# Altium schematic sheet Top.SchDoc(Designator)
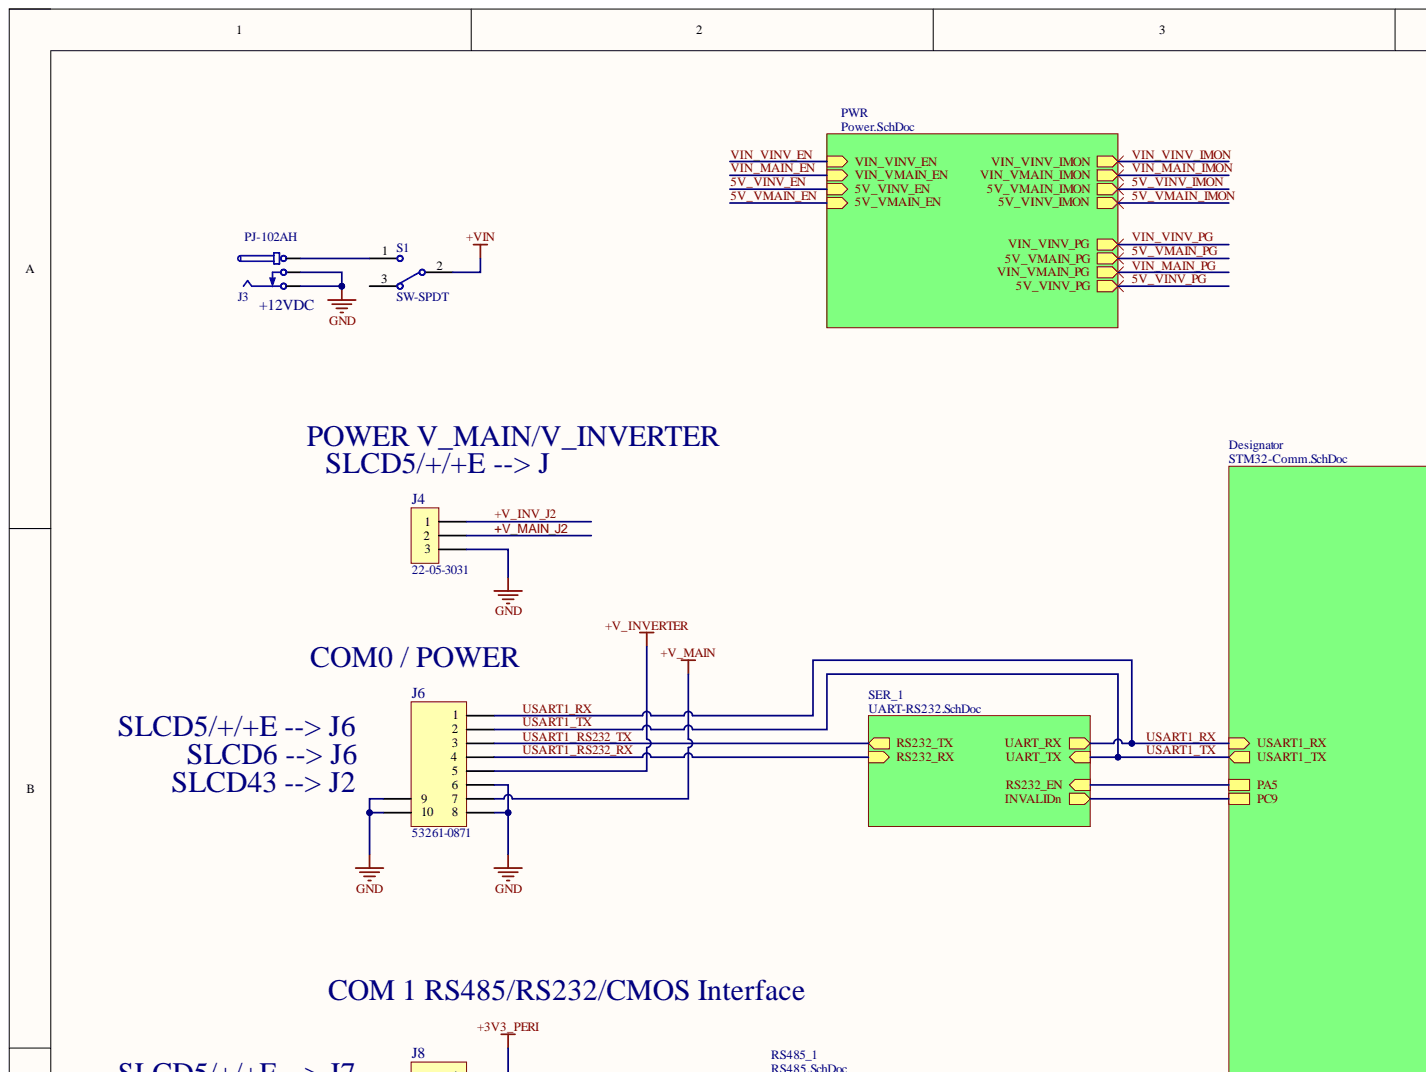
[diagram p0: Top.SchDoc, top left]
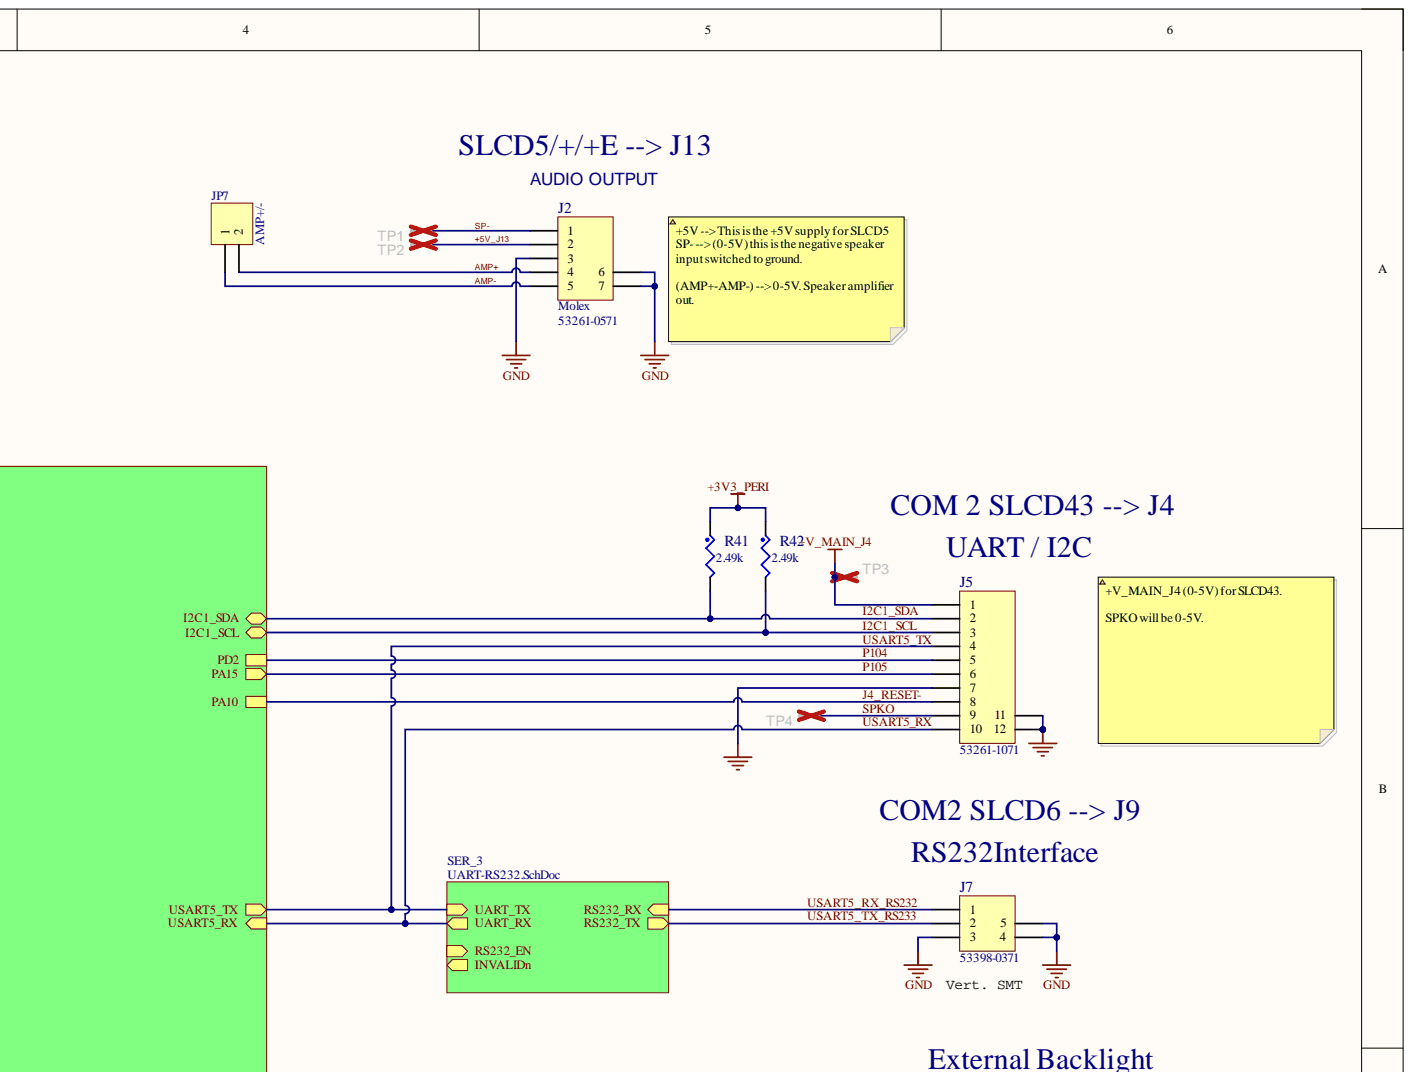
[diagram p1: Top.SchDoc, top right]
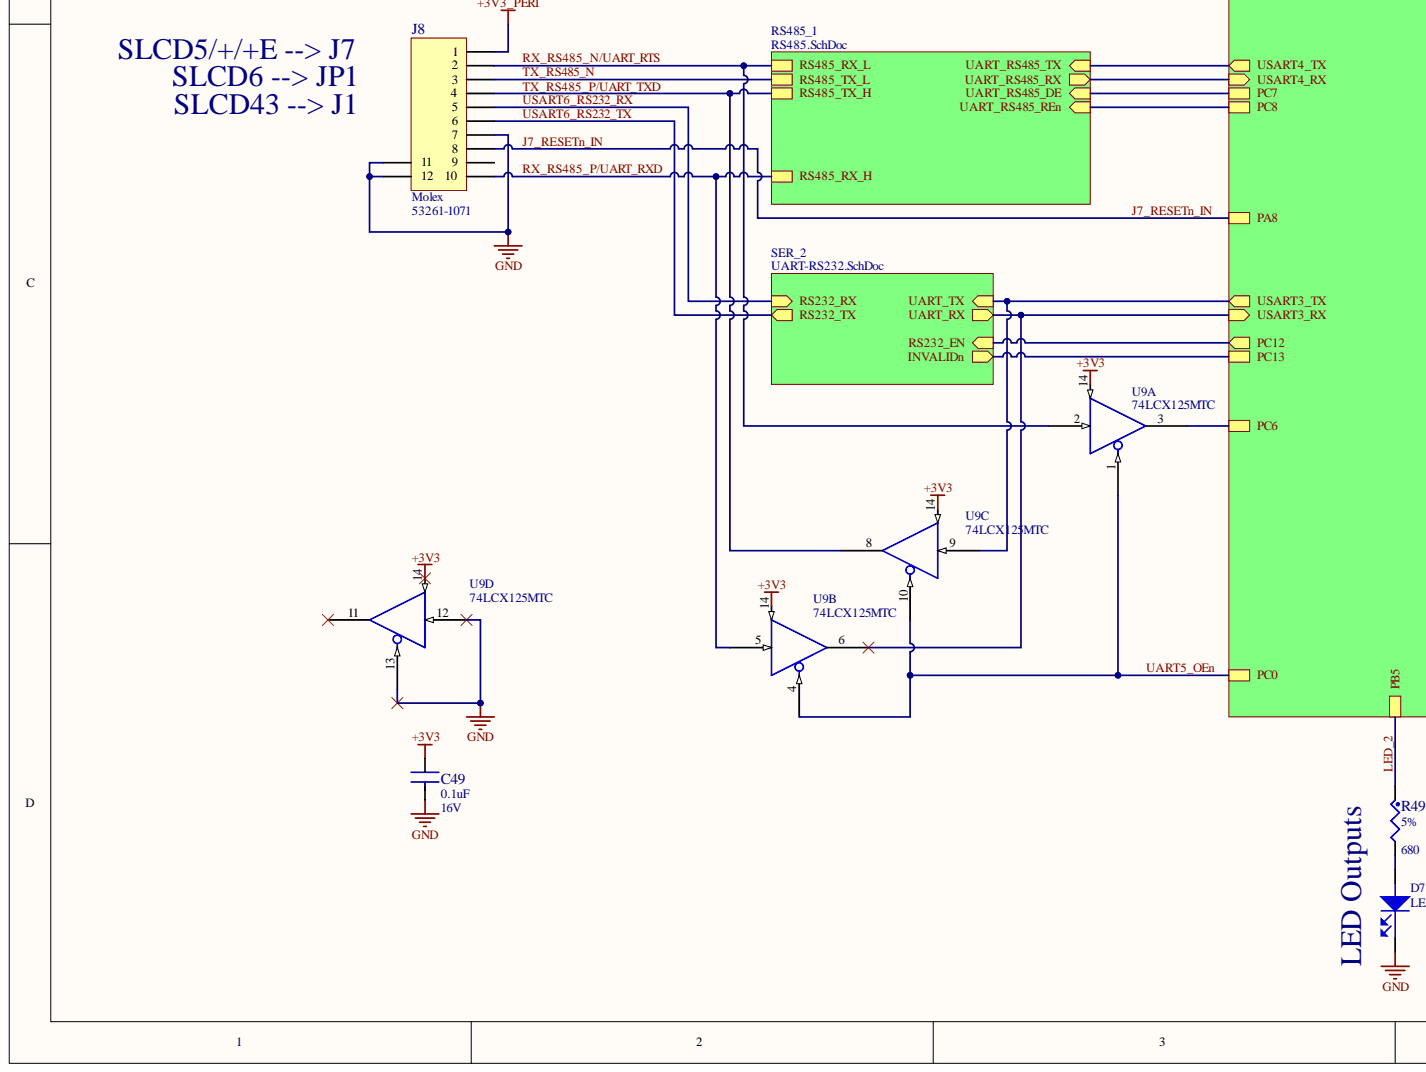
[diagram p2: Top.SchDoc, bottom left]
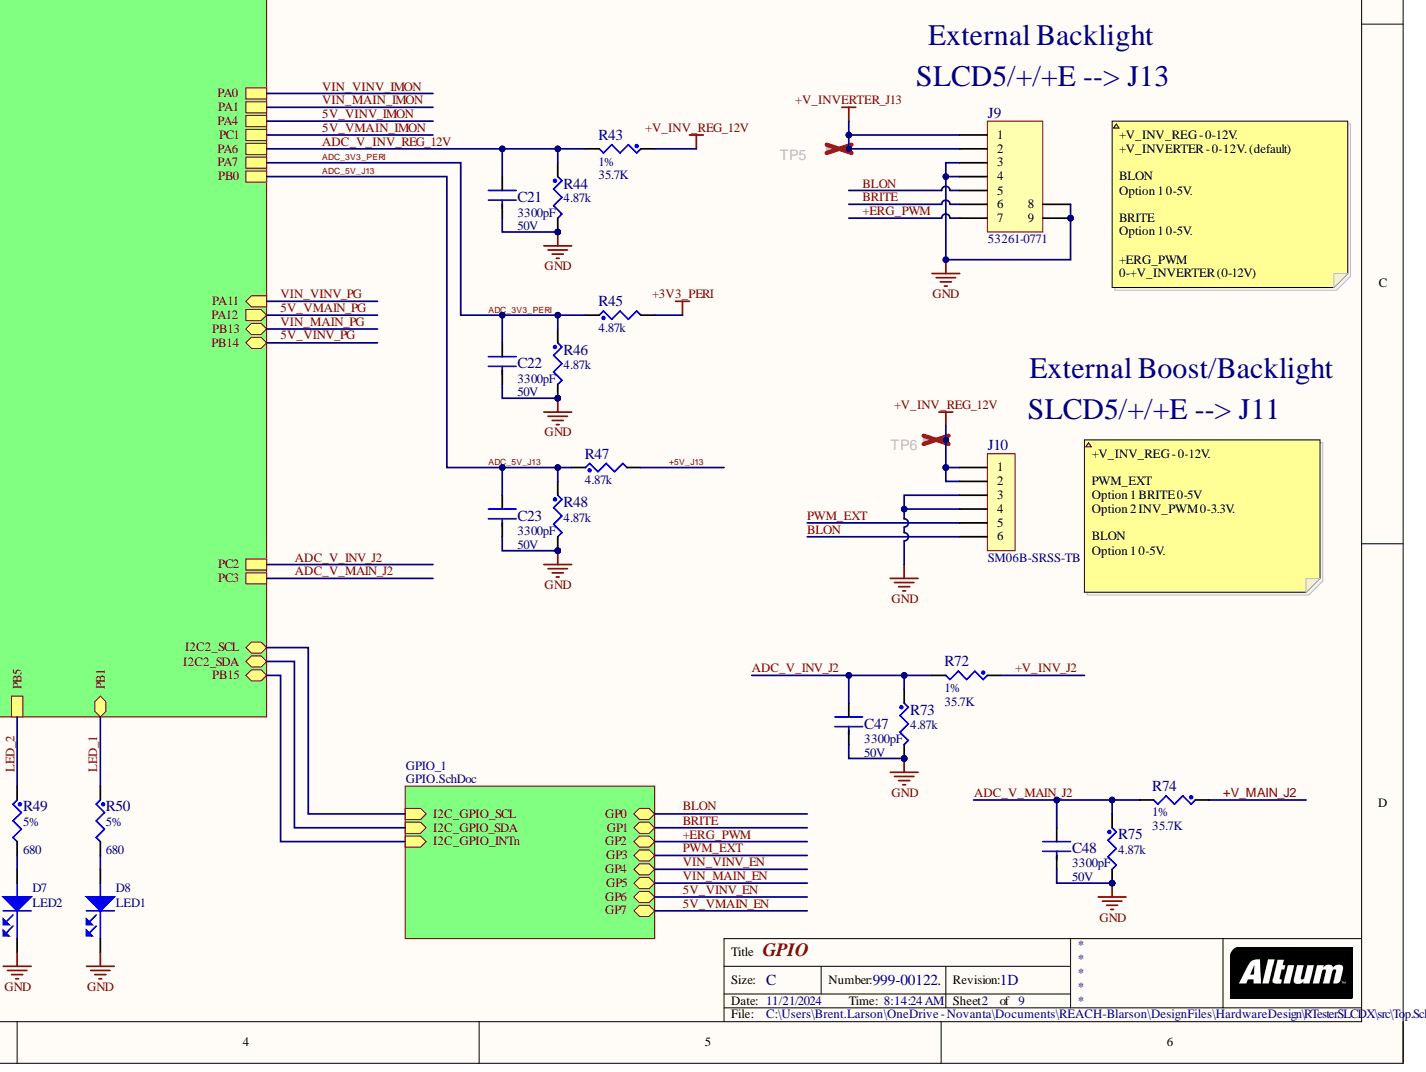
[diagram p3: Top.SchDoc, bottom right]

## Parts
C21  Val: 3300pF  Volt: 50V  [scap-0603]  (hidden comment: C0603C332K5RACTU)
C22  Val: 3300pF  Volt: 50V  [scap-0603]  (hidden comment: C0603C332K5RACTU)
C23  Val: 3300pF  Volt: 50V  [scap-0603]  (hidden comment: C0603C332K5RACTU)
C47  Val: 3300pF  Volt: 50V  [scap-0603]  (hidden comment: C0603C332K5RACTU)
C48  Val: 3300pF  Volt: 50V  [scap-0603]  (hidden comment: C0603C332K5RACTU)
C49  Val: 0.1uF  Volt: 16V  [scap-0603]  (hidden comment: C0603C104K4RACTU)
D7  Val: LED2  [sled-0603]  (hidden comment: LNJ308G8LRA)
D8  Val: LED1  [sled-0603]  (hidden comment: LNJ308G8LRA)
J2  53261-0571  Mfg: Molex  [scon-MLX_53261-05]
J3  PJ-102AH  [TCON-CUI_PJ-102BH]
J4  22-05-3031  [PENDING]
J5  53261-1071  [scon-MLX_53261-10]
J6  53261-0871  [scon-MLX_53261-08]
J7  53398-0371  [PENDING]
J8  53261-1071  Mfg: Molex  [scon-MLX_53261-10]
J9  53261-0771  [scon-MLX_53261-07]
J10  SM06B-SRSS-TB  [PENDING]
JP7  AMP+/-  [HDR1X2]
R41  Val: 2.49k  [sres-0402]  (hidden comment: ERJ-2RKF2491X)
R42  Val: 2.49k  [sres-0402]  (hidden comment: ERJ-2RKF2491X)
R43  Tol: 1%  Val: 35.7K  [sres-0603]  (hidden comment: RC0603FR-0735K7L)
R44  Val: 4.87k  [sres-0402]  (hidden comment: ERJ-2RKF4871X)
R45  Val: 4.87k  [sres-0402]  (hidden comment: ERJ-2RKF4871X)
R46  Val: 4.87k  [sres-0402]  (hidden comment: ERJ-2RKF4871X)
R47  Val: 4.87k  [sres-0402]  (hidden comment: ERJ-2RKF4871X)
R48  Val: 4.87k  [sres-0402]  (hidden comment: ERJ-2RKF4871X)
R49  Tol: 5%  [sres-0603]  (hidden comment: ERJ-3GEYJ681V)
R50  Tol: 5%  [sres-0603]  (hidden comment: ERJ-3GEYJ681V)
R72  Tol: 1%  Val: 35.7K  [sres-0603]  (hidden comment: RC0603FR-0735K7L)
R73  Val: 4.87k  [sres-0402]  (hidden comment: ERJ-2RKF4871X)
R74  Tol: 1%  Val: 35.7K  [sres-0603]  (hidden comment: RC0603FR-0735K7L)
R75  Val: 4.87k  [sres-0402]  (hidden comment: ERJ-2RKF4871X)
S1  SW-SPDT  [TL36WW15050]
TP1  ART-TP-40MIL  [PENDING]  (value not drawn)
TP2  ART-TP-40MIL  [PENDING]  (value not drawn)
TP3  ART-TP-40MIL  [PENDING]  (value not drawn)
TP4  ART-TP-40MIL  [PENDING]  (value not drawn)
TP5  ART-TP-40MIL  [PENDING]  (value not drawn)
TP6  ART-TP-40MIL  [PENDING]  (value not drawn)
U9  74LCX125MTC  [PENDING]  (parts A,B,C,D)

## Nets
+3V3: C49.1 U9.14
+3V3_PERI: J8.1 R41.1 R42.1 R45.2
+V_INV_REG_12V: J10.1 J10.2 R43.1 TP6.1
+V_INVERTER: J6.5
+V_INVERTER_J13: J9.1 J9.2 TP5.1
+V_MAIN: J6.7
+V_MAIN_J4: J5.1 TP3.1
+VIN: S1.2
GND: C21.2 C22.2 C23.2 C47.2 C48.2 C49.2 D7.C D8.C J2.3 J2.6 J2.7 J3.2 J3.3 J4.3 J5.7 J5.11 J5.12 J6.6 J6.8 J6.9 J6.10 J7.3 J7.4 J7.5 J8.7 J8.11 J8.12 J9.3 J9.4 J9.8 J9.9 J10.3 J10.4 R44.2 R46.2 R48.2 R73.2 R75.2 U9.7 U9.12 U9.13
5V_VINV_EN: J2.2 R47.2 TP2.1  [also labelled +5V_J13]
+ERG_PWM: J9.7
+V_INV_J2: J4.1 R72.1
+V_MAIN_J2: J4.2 R74.1
ADC_3V3_PERI: C22.1 R45.1 R46.1
ADC_5V_J13: C23.1 R47.1 R48.1
ADC_V_INV_J2: C47.1 R72.2 R73.1
ADC_V_INV_REG_12V: C21.1 R43.2 R44.1
ADC_V_MAIN_J2: C48.1 R74.2 R75.1
AMP+: J2.4 JP7.2
AMP-: J2.5 JP7.1
BLON: J9.5 J10.6
BRITE: J9.6
I2C1_SCL: J5.3 R42.2
I2C1_SDA: J5.2 R41.2
J4_RESET-: J5.8
J7_RESETn_IN: J8.8
LED_1: R50.1
LED_2: R49.1
P104: J5.5
P105: J5.6
PWM_EXT: J10.5
RX_RS485_N/UART_RTS: J8.2 U9.2
RX_RS485_P/UART_RXD: J8.10 U9.5
SP-: J2.1 TP1.1
SPKO: J5.9 TP4.1
TX_RS485_N: J8.3
TX_RS485_P/UART_TXD: J8.4 U9.8
UART5_OEn: U9.1 U9.4 U9.10
USART1_RS232_RX: J6.4
USART1_RS232_TX: J6.3
USART1_RX: J6.1
USART1_TX: J6.2
USART5_RX: J5.10
USART5_RX_RS232: J7.1
USART5_TX: J5.4
USART5_TX_RS233: J7.2
USART6_RS232_RX: J8.5
USART6_RS232_TX: J8.6
NetD7_A: D7.A R49.2
NetD8_A: D8.A R50.2
NetJ3_1: J3.1 S1.1
NetJ8_9: J8.9
NetS1_3: S1.3
NetU9_3: U9.3
NetU9_6: U9.6
NetU9_9: U9.9
NetU9_11: U9.11
5V_VINV_IMON:
5V_VINV_PG:
5V_VMAIN_EN:
5V_VMAIN_IMON:
5V_VMAIN_PG:
I2C2_SCL:
I2C2_SDA:
I2C_GPIO_INTn:
INVALIDn:
PA5:
PC7:
PC8:
PC12:
RS232_EN:
UART_RS485_RX:
UART_RS485_TX:
VIN_MAIN_EN:
VIN_MAIN_IMON:
VIN_MAIN_PG:
VIN_VINV_EN:
VIN_VINV_IMON:
VIN_VINV_PG:

## Library data of the parts
C21-C23 C47 C48 = CAP, SMD, CER 3300pF 50V 10% X7R (0603): Cap, Non-Polorized; Database Table Name: Capacitor$; Mfg: Kemet; Pkg_Size: 0603 (1608 Metric); Tol: 10%; Component Kind: Standard; Mfr_PN: C0603C332K5RACTU; Reach_PN: 11-0148-01; Supplier 1: Digi-Key; Supplier Part Number 1: 399-1086-1-ND; Library Name: REACH TECH.DbLib
C49 = CAP, SMD, CER 0.1UF 16V 10% X7R (0603): Cap, Non-Polorized; Database Table Name: Capacitor$; Mfg: Kemet; Pkg_Size: 0603; Tol: 10%; Component Kind: Standard; Mfr_PN: C0603C104K4RACTU; Reach_PN: 11-0079-00; Supplier 1: Digi-Key; Supplier Part Number 1: 399-1096-6-ND; Library Name: REACH TECH.DbLib
D7 D8 = LED, SMD, GREEN SS TYPE LOW CUR (0603): LED, Pins=[a,c]; Database Table Name: LED$; Mfg: Panasonic-SSG; Pkg_Size: 0603; Value: GRN; Component Kind: Standard; Mfr_PN: LNJ308G8LRA; Reach_PN: 15-0021-01; Supplier 1: Digi-Key; Supplier Part Number 1: P521DKR-ND; Library Name: REACH TECH.DbLib
J2 = CONN, SMD, 5pin, 1.25 Wire-to-board, (Molex 53261-05xx): HDR, 5-PIN with 2 electrical anchor pins; Database Table Name: Connector$; Val: Value; Component Kind: Standard; Mfr_PN: 53261-0571; Reach_PN: 13-0082-00; Supplier 1: Digi-Key; Supplier Part Number 1: WM7623CT-ND; Library Name: REACH TECH.DbLib
J3 = CONN, JACK, CONN PWR JACK 2.1X5.5MM: CONN PWR JACK 2.5X5.5MM HIGH CUR; Database Table Name: Connector$; Mfg: CUI; Component Kind: Standard; Mfr_PN: PJ-102AH; Reach_PN: 13-0107-00; Supplier 1: Digi-Key; Supplier Part Number 1: CP-102AH-ND; Library Name: REACH TECH.DbLib
J4 = CONN, Male Header,  3-Pin .100 R/A, Tin: HDR, 3-pin; Database Table Name: Connector$; Mfg: Molex; Val: Value; Component Kind: Standard; Mfr_PN: 22-05-3031; Reach_PN: 13-0023-03; Supplier 1: Digi-Key; Supplier Part Number 1: WM4301-ND; Library Name: REACH TECH.DbLib
J5 = CONN, SMD, 10pin, 1.25 Wire-to-board, (Molex 53261-10xx): HDR, 10-PIN with 2 electrical anchor pins; Database Table Name: Connector$; Mfg: Molex; Val: Value; Component Kind: Standard; Mfr_PN: 53261-1071; Reach_PN: 13-0058-00; Supplier 1: Digi-Key; Supplier Part Number 1: WM7628DKR-ND; Library Name: REACH TECH.DbLib
J6 = CONN, SMD, 8pin, 1.25 Wire-to-board, (Molex 53261-08xx): HDR, 8-PIN with 2 electrical anchor pins; Database Table Name: Connector$; Mfg: Molex; Val: Value; Component Kind: Standard; Mfr_PN: 53261-0871; Reach_PN: 13-0008-00; Supplier 1: Digi-Key; Supplier Part Number 1: WM7626DKR-ND; Library Name: REACH TECH.DbLib
J7 = CONN, SMD, 1.25mm vertical 3pos.: HDR, 3-PIN with 2 electrical anchor pins; Database Table Name: Connector$; Mfg: Molex; Val: Value; Component Kind: Standard; Mfr_PN: 53398-0371; Reach_PN: 13-0119-00; Supplier 1: Digi-Key; Supplier Part Number 1: WM7607CT-ND; Library Name: REACH TECH.DbLib
J8 = CONN, SMD, 10pin, 1.25 Wire-to-board, (Molex 53261-10xx): HDR, 10-PIN with 2 electrical anchor pins; Database Table Name: Connector$; Val: Value; Component Kind: Standard; Mfr_PN: 53261-1071; Reach_PN: 13-0058-00; Supplier 1: Digi-Key; Supplier Part Number 1: WM7628DKR-ND; Library Name: REACH TECH.DbLib
J9 = CONN, SMD, 7pin, 1.25 Wire-to-board, (Molex 53261-07xx): HDR, 7-PIN with 2 electrical anchor pins; Database Table Name: Connector$; Mfg: Molex; Val: Value; Component Kind: Standard; Mfr_PN: 53261-0771; Reach_PN: 13-0115-00; Supplier 1: Digi-Key; Supplier Part Number 1: WM7625CT-ND; Library Name: REACH TECH.DbLib
J10 = CONN HEADER SMD R/A 6POS 1MM JST SR: HDR, 6-PIN; Database Table Name: Connector$; Mfg: JST; Val: Value; Component Kind: Standard; Mfr_PN: SM06B-SRSS-TB; Reach_PN: 13-0244-01; Supplier 1: Digi-Key; Supplier Part Number 1: 455-SM06B-SRSS-TBTR-ND; Library Name: REACH TECH.DbLib
JP7 = Header 2: Header, 2-Pin; Mfr_PN: PHA116--P0201GFROHS; Mfg: MMT; Component Kind: Standard; LatestRevisionDate: 17-Jul-2002; LatestRevisionNote: Re-released for DXP Platform.; Library Name: Miscellaneous Connectors.IntLib; Reach_PN: 12-0006-00; Signal Integrity: Connector; Publisher: Altium Limited
R41 R42 = RES SMD 2.49K OHM 1% 1/10W 0402: Default 2-pin Resistor; Database Table Name: Resistor$; Mfg: Panasonic-ECG; Pkg_Size: 0402; Tol: 1.00%; Watt: 1/10W; Component Kind: Standard; Mfr_PN: ERJ-2RKF2491X; Reach_PN: 10-0356-00; Supplier 1: Digi-Key; Supplier Part Number 1: P2.49KLTR-ND; Library Name: REACH TECH.DbLib
R43 R72 R74 = RES, SMD, 35.7K OHM 1/10W 1% (0603): Default 2-pin Resistor; Database Table Name: Resistor$; Mfg: Yageo; Pkg_Size: 0603; Watt: 1/10W; Component Kind: Standard; Mfr_PN: RC0603FR-0735K7L; Reach_PN: 10-0325-00; Supplier 1: Digi-Key; Supplier Part Number 1: 311-35.7KHRCT-ND; Library Name: REACH TECH.DbLib
R44-R48 R73 R75 = RES SMD 4.87K OHM 1% 1/10W 0402: Default 2-pin Resistor; Database Table Name: Resistor$; Mfg: Panasonic-ECG; Tol: 1.00%; Watt: 1/10W; Component Kind: Standard; Mfr_PN: ERJ-2RKF4871X; Reach_PN: 10-0417-00; Supplier 1: Digi-Key; Supplier Part Number 1: P4.87KLTR-ND; Library Name: REACH TECH.DbLib
R49 R50 = RES, SMD, 680 OHM 1/10W 5% (0603): Default 2-pin Resistor; Database Table Name: Resistor$; Mfg: Panasonic-ECG; Pkg_Size: 0603 (1608 Metric); Val: 680; Component Kind: Standard; Mfr_PN: ERJ-3GEYJ681V; Reach_PN: 10-0264-00; Supplier 1: Digi-Key; Supplier Part Number 1: P680GDKR-ND; Library Name: REACH TECH.DbLib
S1 = SW-SPDT: SWITCH, TOGGLE SPDT 5A 120V; Mfr_PN: 100SP1T1B4M2QE; Mfg: E-Switch; Component Kind: Standard; ComponentLink1Description: Manufacturer Link; ComponentLink1URL: http://www.apem.com; DatasheetVersion: 05-Dec-2000; LatestRevisionDate: 17-Dec-2003; LatestRevisionNote: Schematic revised, PCB Footprint replaced.; Library Name: Miscellaneous Devices.IntLib; PackageDescription: Switch; 4 Leads; PackageReference: TL36WW15050; Published: 24-Mar-1999; Reach_PN: 17-0014-00; Publisher: Altium Limited
TP1-TP6 = TP, Test Point 40mil: Test Point, SMD, 35mil pad w/silk ring; Database Table Name: Test-Points$; Val: 40mil; Volt: Volts; Component Kind: Standard; Mfg: ART-TP-40MIL; Mfr_PN: ART-TP-40MIL; Reach_PN: ART-TP_40MIL_ANY; Supplier 1: ART-TP; Supplier Part Number 1: ART-TP; Tol: Tol; Library Name: REACH TECH.DbLib
U9 = IC, SMD, BUFFER QUAD LV N-INV (14-TSSOP): Database Table Name: IC Digital$; Mfg: Fairchild; Component Kind: Standard; Mfr_PN: 74LCX125MTC; Reach_PN: 02-0139-01; Supplier 1: Digi-Key; Val: 74LCX125MTC; Library Name: REACH TECH.DbLib
